# Altium schematic sheet Sheet1.SchDoc
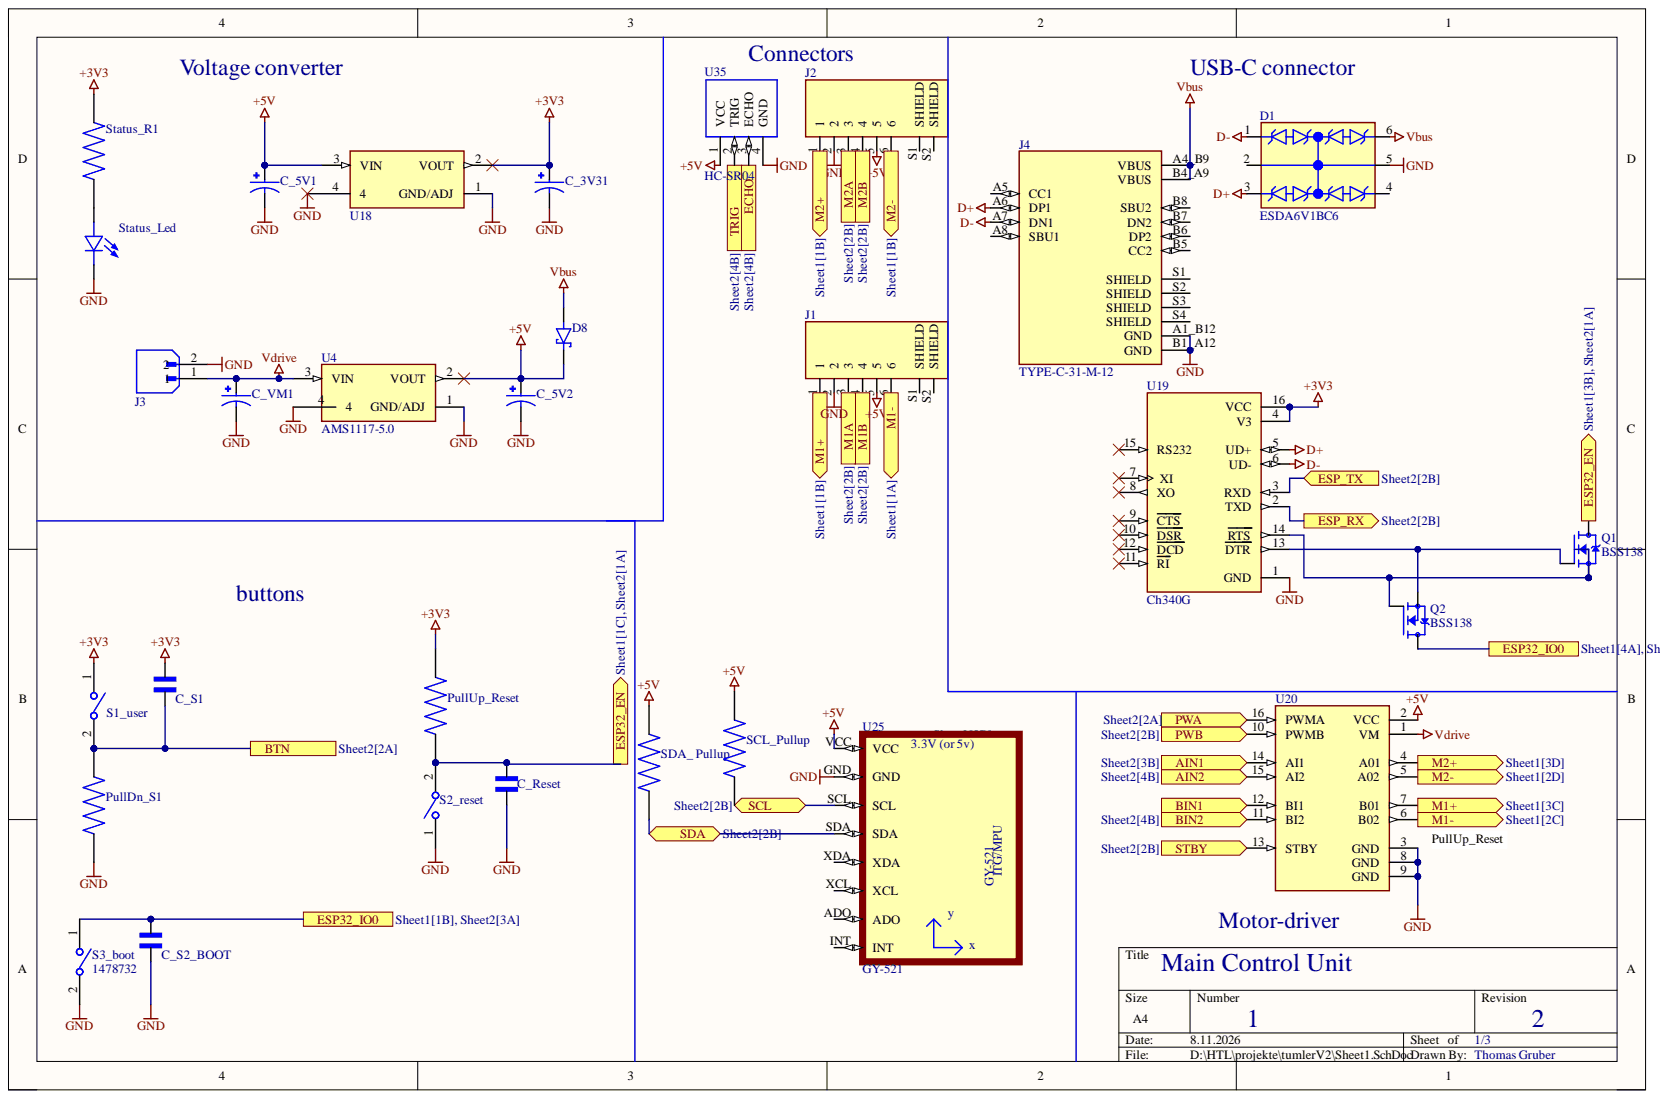
[diagram p0: Sheet1.SchDoc]

## Parts
C_3V31  EEEFK1E100R  [CAP_EEEFK1E100R]  (value not drawn)
C_5V1  EEEFK1E100R  [CAP_EEEFK1E100R]  (value not drawn)
C_5V2  EEEFK1E100R  [CAP_EEEFK1E100R]  (value not drawn)
C_Reset  VJ0805A681KXCAP  [CAPC2012X145N]  (value not drawn)
C_S1  VJ0805A681KXCAP  [CAPC2012X145N]  (value not drawn)
C_S2_BOOT  VJ0805A681KXCAP  [CAPC2012X145N]  (value not drawn)
C_VM1  EEEFK1E100R  [CAP_EEEFK1E100R]  (value not drawn)
D1  ESDA6V1BC6  [SOT95P280X145-6N]
D8  B5819WS-TP  [SOD2512X115N]  (value not drawn)
J1  S6B-PH-SM4-TB (LF)(SN)  [JST_S6B-PH-SM4-TB(LF)(SN)]  (value not drawn)
J2  S6B-PH-SM4-TB (LF)(SN)  [JST_S6B-PH-SM4-TB(LF)(SN)]  (value not drawn)
J3  S2B-XH-A-1(LF)(SN)  [JST_S2B-XH-A-1(LF)(SN)]  (value not drawn)
J4  TYPE-C-31-M-12  [HRO_TYPE-C-31-M-12]
PullDn_S1  0805W8F1002T5E  [RESC2012X65N]  (value not drawn)
PullUp_Reset  0805W8F1002T5E  [RESC2012X65N]  (value not drawn)
Q1  BSS138  [SOT95P240X111-3N]
Q2  BSS138  [SOT95P240X111-3N]
S1_user  1478732  [SW_147873-2]  (value not drawn)
S2_reset  1478732  [SW_147873-2]  (value not drawn)
S3_boot  1478732  [SW_147873-2]
SCL_Pullup  0805W8F1002T5E  [RESC2012X65N]  (value not drawn)
SDA__Pullup  0805W8F1002T5E  [RESC2012X65N]  (value not drawn)
Status_Led  APHCM2012SURCK-F01  [LED_APHCM2012SURCK-F01]  (value not drawn)
Status_R1  ERA6AHD200V  [RES_ERA6AHD200V]  (value not drawn)
U4  AMS1117-5.0  [SOT229P700X180-4N]
U18  AMS1117-3.3  [SOT229P700X180-4N]  (value not drawn)
U19  Ch340G  [SOIC127P600X180-16N]
U20  ROB-14450  [MODULE_ROB-14450]  (value not drawn)
U25  GY-521  [GY-521]
U35  HC-SR04  [XCVR_HC-SR04]

## Ports
AIN1, AIN2, BIN1, BIN2, BTN, ECHO, ESP32_EN, ESP32_IO0, ESP_RX, ESP_TX, M1+, M1-, M1A, M1B, M2+, M2-, M2A, M2B, PWA, PWB, SCL, SDA, STBY, TRIG

## Nets
+3V3: C_3V31.1 C_S1.2 PullUp_Reset.2 S1_user.1 Status_R1.2 U18.2(VOUT) U19.4(V3) U19.16(VCC)
+5V: C_5V1.1 C_5V2.1 D8.C J1.5 J2.5 SCL_Pullup.2 SDA__Pullup.2 U4.2(VOUT) U18.3(VIN) U20.2(VCC) U25.VCC U35.1(VCC)
D+: D1.3 J4.A6(DP1) U19.5(UD+)
D-: D1.1 J4.A7(DN1) U19.6(UD-)
GND: C_3V31.2 C_5V1.2 C_5V2.2 C_Reset.1 C_S2_BOOT.1 C_VM1.2 D1.5 J1.2 J2.2 J3.2 J4.A1_B12(GND) J4.B1_A12(GND) PullDn_S1.1 S2_reset.1 S3_boot.2 Status_Led.1 U4.1(GND/ADJ) U4.4 U18.1(GND/ADJ) U18.4 U19.1(GND) U20.3(GND) U20.8(GND) U20.9(GND) U25.GND U35.4(GND)
Vbus: D1.6 D8.A J4.A4_B9(VBUS) J4.B4_A9(VBUS)
Vdrive: C_VM1.1 J3.1 U4.3(VIN) U20.1(VM)
AIN1: U20.14(AI1) ; port AIN1
AIN2: U20.15(AI2) ; port AIN2
BIN1: U20.12(BI1) ; port BIN1
BIN2: U20.11(BI2) ; port BIN2
BTN: C_S1.1 PullDn_S1.2 S1_user.2 ; port BTN
ECHO: U35.3(ECHO) ; port ECHO
ESP32_EN: C_Reset.2 PullUp_Reset.1 Q1.3 S2_reset.2 ; port ESP32_EN
ESP32_IO0: C_S2_BOOT.2 Q2.3 S3_boot.1 ; port ESP32_IO0
ESP_RX: U19.2(TXD) ; port ESP_RX
ESP_TX: U19.3(RXD) ; port ESP_TX
M1A: J1.3 ; port M1A
M1B: J1.4 ; port M1B
M2A: J2.3 ; port M2A
M2B: J2.4 ; port M2B
PWA: U20.16(PWMA) ; port PWA
PWB: U20.10(PWMB) ; port PWB
SCL: SCL_Pullup.1 U25.SCL ; port SCL
SDA: SDA__Pullup.1 U25.SDA ; port SDA
STBY: U20.13(STBY) ; port STBY
TRIG: U35.2(TRIG) ; port TRIG
NetJ1_1: J1.1 U20.7(B01)
NetJ1_6: J1.6 U20.6(B02)
NetJ2_1: J2.1 U20.4(A01)
NetJ2_6: J2.6 U20.5(A02)
NetQ1_1: Q1.1 Q2.2 U19.13(DTR)
NetQ1_2: Q1.2 Q2.1 U19.14(RTS)
NetStatus_Led_2: Status_Led.2 Status_R1.1

Not connected: D1 2 4; J1 S1(SHIELD) S2(SHIELD); J2 S1(SHIELD) S2(SHIELD); J4 A5(CC1) A8(SBU1) B5(CC2) B6(DP2) B7(DN2) B8(SBU2) S1(SHIELD) S2(SHIELD) S3(SHIELD) S4(SHIELD); U19 7(XI) 8(XO) 9(CTS) 10(DSR) 11(RI) 12(DCD) 15(RS232); U25 ADO INT XCL XDA

## Library data of the parts
C_3V31 C_5V1 C_5V2 C_VM1 = EEEFK1E100R: 10ÂµF 25V Aluminum Electrolytic Capacitors Radial, Can - SMD 2000 Hrs @ 105Â°C; MF: Panasonic; Value: 10µ; Check_prices: https://www.snapeda.com/parts/EEEFK1E100R/Panasonic/view-part/5793802/?ref=eda; Component Kind: Standard; Digi-Key_Part_Number: PCE3795CT-ND; MP: EEEFK1E100R; Package: RADIAL-2 Panasonic; SnapEDA_Link: https://www.snapeda.com/parts/EEEFK1E100R/Panasonic/view-part/5793802/?ref=snap
C_Reset C_S1 C_S2_BOOT = VJ0805A681KXCAP: CAP CER 100nF 200V C0G/NP0 0805; MF: Vishay Vitramon; Value: 100n; Check_prices: https://www.snapeda.com/parts/VJ0805A681KXCAP/Vishay/view-part/?ref=eda; Component Kind: Standard; Library Name: VJ0805A681KXCAP.IntLib; MP: VJ0805A681KXCAP; Package: 2012 Vishay Vitramon; SnapEDA_Link: https://www.snapeda.com/parts/VJ0805A681KXCAP/Vishay/view-part/?ref=snap
D1 = ESDA6V1BC6: - Clamp - Ipp Tvs Diode Surface Mount SOT-23-6; MF: STMicroelectronics; Availability: In Stock; Check_prices: https://www.snapeda.com/parts/ESDA6V1BC6/STMicroelectronics/view-part/?ref=eda; Component Kind: Standard; MP: ESDA6V1BC6; Package: SOT-23-6 STMicroelectronics; Price: None; Purchase-URL: https://pricing.snapeda.com/search/part/ESDA6V1BC6/?ref=eda; SnapEDA_Link: https://www.snapeda.com/parts/ESDA6V1BC6/STMicroelectronics/view-part/?ref=snap; Supplier 1: Mouser; Supplier Part Number 1: 511-ESDA6V1BC6
D8 = B5819WS-TP: Diode Schottky 40 V 1A Surface Mount SOD-323; MF: Micro Commercial; Check_prices: https://www.snapeda.com/parts/B5819WS-TP/Micro+Commercial/view-part/?ref=eda; Component Kind: Standard; Library Name: B5819WS-TP.IntLib; MP: B5819WS-TP; Package: SOD-323 Taiwan Semiconductor Corporation; SnapEDA_Link: https://www.snapeda.com/parts/B5819WS-TP/Micro+Commercial/view-part/?ref=snap
J1 J2 = S6B-PH-SM4-TB (LF)(SN): Connector Header Surface Mount, Right Angle 6 position 0.079 (2.00mm); MF: JST Sales; Check_prices: https://www.snapeda.com/parts/S6B-PH-SM4-TB%20(LF)(SN)/JST/view-part/?ref=eda; Component Kind: Standard; MP: S6B-PH-SM4-TB (LF)(SN); Package: None; SnapEDA_Link: https://www.snapeda.com/parts/S6B-PH-SM4-TB%20(LF)(SN)/JST/view-part/?ref=snap; Supplier 1: Rs; Supplier Part Number 1: 6881369P
J3 = S2B-XH-A-1(LF)(SN): Connector Header Through Hole, Right Angle 2 position 0.098 (2.50mm); MF: JST Sales; Check_prices: https://www.snapeda.com/parts/S2B-XH-A-1(LF)(SN)/JST+Sales+America+Inc./view-part/?ref=eda; Component Kind: Standard; MP: S2B-XH-A-1(LF)(SN); Package: None; SnapEDA_Link: https://www.snapeda.com/parts/S2B-XH-A-1(LF)(SN)/JST+Sales+America+Inc./view-part/?ref=snap; Supplier 1: Mouser; Supplier Part Number 1: 306-S2BXHA1LFSN
J4 = TYPE-C-31-M-12: USB Connectors 24 Receptacle 1 8.94*7.3mm RoHS; MF: HRO Electronics Co., Ltd.; Check_prices: https://www.snapeda.com/parts/TYPE-C-31-M-12/HRO+Electronics+Co.%252C+Ltd./view-part/?ref=eda; Component Kind: Standard; Library Name: TYPE-C-31-M-12.IntLib; MP: TYPE-C-31-M-12; Package: Package; SnapEDA_Link: https://www.snapeda.com/parts/TYPE-C-31-M-12/HRO+Electronics+Co.%252C+Ltd./view-part/?ref=snap
PullDn_S1 PullUp_Reset SCL_Pullup SDA__Pullup = 0805W8F1002T5E: 10K Ohm 1/8W Thick Film Resistor 0805 Chip - Surface Mount; MF: Uni-Royal; Check_prices: https://www.snapeda.com/parts/0805W8F1002T5E/Uni-Royal/view-part/?ref=eda; Component Kind: Standard; MP: 0805W8F1002T5E; Package: 0805-2 ROYAL; SnapEDA_Link: https://www.snapeda.com/parts/0805W8F1002T5E/Uni-Royal/view-part/?ref=snap; Value: 10k
Q1 Q2 = BSS138: N-Channel 50 V 220mA (Ta) 350mW (Ta) Surface Mount SOT-23-3; MF: onsemi; Check_prices: https://www.snapeda.com/parts/BSS138/Onsemi/view-part/?ref=eda; Component Kind: Standard; MP: BSS138; Package: SOT-23-3 ON Semiconductor; SnapEDA_Link: https://www.snapeda.com/parts/BSS138/Onsemi/view-part/?ref=snap; Supplier 1: Future Electronics; Supplier Part Number 1: 7723794
S1_user S2_reset S3_boot = 1478732: Switch, Switch,Tact,Smt,J-Lead,4.3Mm | TE Connectivity 147873-2; Configuration_Pole-Throw: Single Pole - Single Throw; Contact_Current_Rating: 50 mA; Check_prices: https://www.snapeda.com/parts/147873-2/TE+Connectivity+ALCOSWITCH+Switches/view-part/?ref=eda; Component Kind: Standard; MF: TE Connectivity; MP: 147873-2; Package: None; SnapEDA_Link: https://www.snapeda.com/parts/147873-2/TE+Connectivity+ALCOSWITCH+Switches/view-part/?ref=snap; Library Name: Snapmagic.DbLib
Status_Led = APHCM2012SURCK-F01: Red 630nm LED Indication - Discrete 1.95V 0805 (2012 Metric); MF: Kingbright; Check_prices: https://www.snapeda.com/parts/APHCM2012SURCK-F01/Kingbright/view-part/?ref=eda; Component Kind: Standard; Library Name: APHCM2012SURCK-F01.IntLib; MP: APHCM2012SURCK-F01; Package: 0805 Kingbright; SnapEDA_Link: https://www.snapeda.com/parts/APHCM2012SURCK-F01/Kingbright/view-part/?ref=snap
Status_R1 = ERA6AHD200V: Value: 200; Availability: Unavailable; Component Kind: Standard; Library Name: ERA6AHD200V.SchLib; MP: ERA6AHD200V; Package: None; Price: None
U4 = AMS1117-5.0: Database Table Name: components; MF: ams; Check_prices: https://www.snapeda.com/parts/AMS1117-5.0/ams/view-part/?ref=eda; Component Kind: Standard; ID: AMS1117-5.0; MP: AMS1117-5.0; Package: SOT-223 Seeed Technology; SnapEDA_Link: https://www.snapeda.com/parts/AMS1117-5.0/ams/view-part/?ref=snap; Library Name: Snapmagic.DbLib
U18 = AMS1117-3.3: AMS1117-3v3; Database Table Name: components; MF: Advanced Monolithic Systems; Check_prices: https://www.snapeda.com/parts/AMS1117-3.3/Advanced+Monolithic+Systems/view-part/?ref=eda; Component Kind: Standard; ID: AMS1117-3.3; MP: AMS1117-3.3; Package: SOT-223 Seeed Technology; SnapEDA_Link: https://www.snapeda.com/parts/AMS1117-3.3/Advanced+Monolithic+Systems/view-part/?ref=snap; Library Name: Snapmagic.DbLib
U19 = Ch340G: USB Interface Integrated Circuit; MF: WCH; Check_prices: https://www.snapeda.com/parts/Ch340G/WCH/view-part/?ref=eda; Component Kind: Standard; MP: Ch340G; Package: None; SnapEDA_Link: https://www.snapeda.com/parts/Ch340G/WCH/view-part/?ref=snap
U20 = ROB-14450: TB6612FNG Motor Controller/Driver Power Management Evaluation Board; MF: SparkFun Electronics; Availability: In Stock; Check_prices: https://www.snapeda.com/parts/ROB-14450/SparkFun%20Electronics/view-part/2656367/?ref=eda; Component Kind: Standard; MP: ROB-14450; Package: None; Price: None; SnapEDA_Link: https://www.snapeda.com/parts/ROB-14450/SparkFun%20Electronics/view-part/2656367/?ref=snap
U25 = GY-521: Triple Axis Accelerometer Gyro Breakout; MF: GODREAM FORDREAM CO., LIMITED; Check_prices: https://www.snapeda.com/parts/GY-521/GODREAM+FORDREAM+CO.%252C+LIMITED/view-part/?ref=eda; Component Kind: Standard; Library Name: GY-521.SchLib; MP: GY-521; Package: Package; SnapEDA_Link: https://www.snapeda.com/parts/GY-521/GODREAM+FORDREAM+CO.%252C+LIMITED/view-part/?ref=snap
U35 = HC-SR04: Ultrasonic Sensor Module; Availability: Unavailable; Component Kind: Standard; Library Name: HC-SR04.IntLib; MP: HC-SR04; Package: None; Price: None
